# Altium schematic sheet AquaboxII.SchDoc
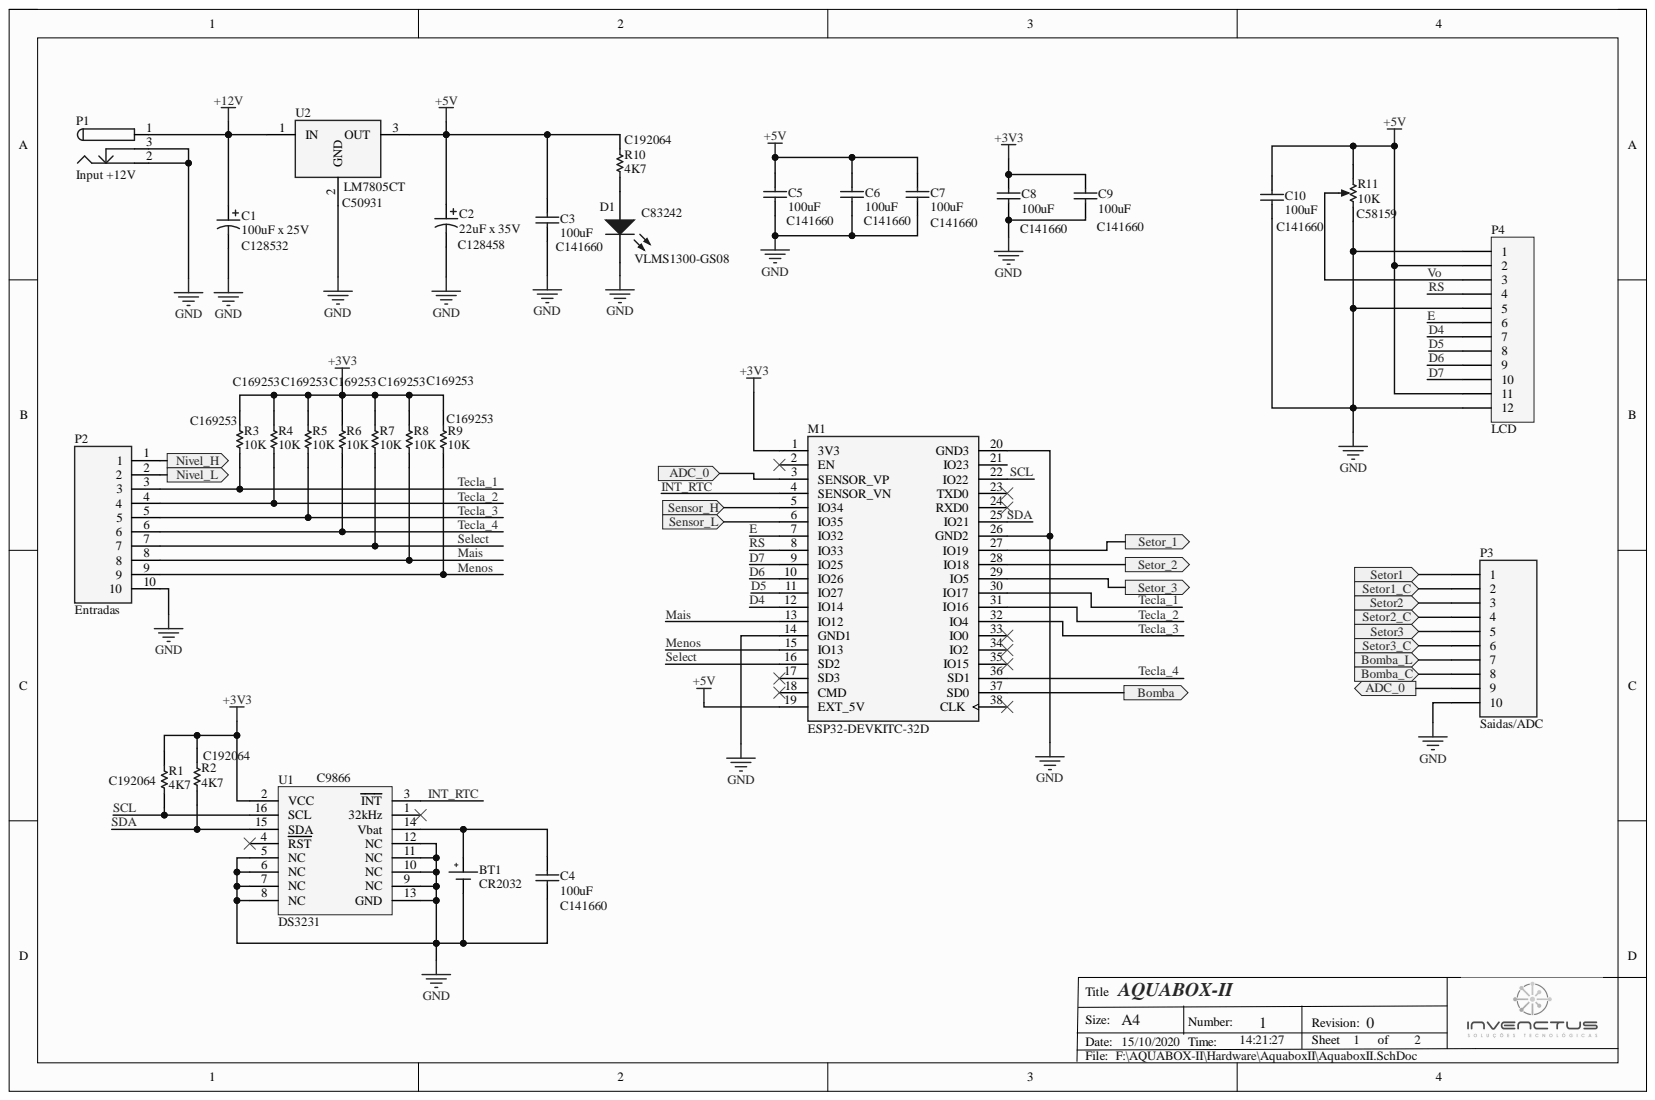
[diagram p0: AquaboxII.SchDoc]

## Parts
BT1  CR2032  [BAT CR2032]
C1  100uF x 25V  Capacitance: 100uF  Supplier Part Number 1: C128532  [Cap_Al_SMD_C6_6.6x6.6mm]
C2  22uF x 35V  Capacitance: 22uF  Supplier Part Number 1: C128458  [Cap_Al_SMD_C6_6.6x6.6mm]
C3  100uF  Supplier Part Number 1: C141660  [C2012[0805]]
C4  100uF  Supplier Part Number 1: C141660  [C2012[0805]]
C5  100uF  Supplier Part Number 1: C141660  [C2012[0805]]
C6  100uF  Supplier Part Number 1: C141660  [C2012[0805]]
C7  100uF  Supplier Part Number 1: C141660  [C2012[0805]]
C8  100uF  Supplier Part Number 1: C141660  [C2012[0805]]
C9  100uF  Supplier Part Number 1: C141660  [C2012[0805]]
C10  100uF  Supplier Part Number 1: C141660  [C2012[0805]]
D1  VLMS1300-GS08  Supplier Part Number 1: C83242  [LED-0603-R]
M1  ESP32-DEVKITC-32D  [MODULE_ESP32-DEVKITC-32D]
P1  Input +12V  [BARREL2.5]
P2  Entradas  [Block 10 Vias 5mm]
P3  Saidas/ADC  [Block 10 Vias 5mm]
P4  LCD  [HDR1X12]
R1  4K7  Supplier Part Number 1: C192064  [R2012[0805]]
R2  4K7  Supplier Part Number 1: C192064  [R2012[0805]]
R3  10K  Supplier Part Number 1: C169253  [R2012[0805]]
R4  10K  Supplier Part Number 1: C169253  [R2012[0805]]
R5  10K  Supplier Part Number 1: C169253  [R2012[0805]]
R6  10K  Supplier Part Number 1: C169253  [R2012[0805]]
R7  10K  Supplier Part Number 1: C169253  [R2012[0805]]
R8  10K  Supplier Part Number 1: C169253  [R2012[0805]]
R9  10K  Supplier Part Number 1: C169253  [R2012[0805]]
R10  4K7  Supplier Part Number 1: C192064  [R2012[0805]]
R11  10K  Supplier Part Number 1: C58159  [VR6]
U1  DS3231  Supplier Part Number 1: C9866  [SOIC-16 - W16 (300'')]
U2  LM7805CT  Supplier Part Number 1: C50931  [TO254P470X1028X1955-3P]

## Ports
ADC_0, Bomba, Bomba_C, Bomba_L, Nivel_H, Nivel_L, Sensor_H, Sensor_L, Setor1, Setor1_C, Setor2, Setor2_C, Setor3, Setor3_C, Setor_1, Setor_2, Setor_3

## Nets
+3V3: C8.2 C9.2 M1.1(3V3) R1.2 R2.2 R3.2 R4.2 R5.2 R6.2 R7.2 R8.2 R9.2 U1.2(VCC)
+5V: C2.1 C3.2 C5.2 C6.2 C7.2 C10.2 M1.19(EXT_5V) P4.2 P4.11 R10.2 R11.1 U2.3
+12V: C1.1 P1.1 U2.1
GND: BT1.2 C1.2 C2.2 C3.1 C4.1 C5.1 C6.1 C7.1 C8.1 C9.1 C10.1 D1.2 M1.14(GND1) M1.20(GND3) M1.26(GND2) P1.2 P1.3 P2.10 P3.10 P4.1 P4.5 P4.12 R11.2 U1.5(NC) U1.6(NC) U1.7(NC) U1.8(NC) U1.9(NC) U1.10(NC) U1.11(NC) U1.12(NC) U1.13(GND) U2.2
ADC_0: M1.3(SENSOR_VP) P3.9 ; port ADC_0
Bomba: M1.37(SD0) ; port Bomba
Bomba_C: P3.8 ; port Bomba_C
Bomba_L: P3.7 ; port Bomba_L
D4: M1.12(IO14) P4.7
D5: M1.11(IO27) P4.8
D6: M1.10(IO26) P4.9
D7: M1.9(IO25) P4.10
E: M1.7(IO32) P4.6
INT_RTC: M1.4(SENSOR_VN) U1.3(INT)
Mais: M1.13(IO12) P2.8 R8.1
Menos: M1.15(IO13) P2.9 R9.1
Nivel_H: P2.1 ; port Nivel_H
Nivel_L: P2.2 ; port Nivel_L
RS: M1.8(IO33) P4.4
SCL: M1.22(IO22) R1.1 U1.16(SCL)
SDA: M1.25(IO21) R2.1 U1.15(SDA)
Select: M1.16(SD2) P2.7 R7.1
Sensor_H: M1.5(IO34) ; port Sensor_H
Sensor_L: M1.6(IO35) ; port Sensor_L
Setor1: P3.1 ; port Setor1
Setor1_C: P3.2 ; port Setor1_C
Setor2: P3.3 ; port Setor2
Setor2_C: P3.4 ; port Setor2_C
Setor3: P3.5 ; port Setor3
Setor3_C: P3.6 ; port Setor3_C
Setor_1: M1.27(IO19) ; port Setor_1
Setor_2: M1.28(IO18) ; port Setor_2
Setor_3: M1.29(IO5) ; port Setor_3
Tecla_1: M1.30(IO17) P2.3 R3.1
Tecla_2: M1.31(IO16) P2.4 R4.1
Tecla_3: M1.32(IO4) P2.5 R5.1
Tecla_4: M1.36(SD1) P2.6 R6.1
Vo: P4.3 R11.3
NetBT1_1: BT1.1 C4.2 U1.14(Vbat)
NetD1_1: D1.1 R10.1

Not connected: M1 2(EN) 17(SD3) 18(CMD) 21(IO23) 23(TXD0) 24(RXD0) 33(IO0) 34(IO2) 35(IO15) 38(CLK); U1 1(32kHz) 4(RST)

## Library data of the parts
BT1 = Bat1: Singlecell Battery; Component Kind: Standard; Library Name: Basic Library.IntLib
C1 = CP: PANASONIC - EEEFK1C101P - CAP, 100µF, 16V, RADIAL, SMD REEL; Dielectric Material: Aluminium; ESR (Equivalent Series Resistance): 360mR; Leakage Current: 3uA; Life (Hours): 2000Hour; Polarity: Polar; Ripple Current: 240mA; Tolerance: 20%; Case/Package: Radial; Category: Aluminum Electrolytic Capacitors; Component Kind: Standard; ComponentLink1Description: https://datasheet.ciiva.com/19479/1357482-19479360.pdf?src-supplier=Jameco; ComponentLink1URL: https://datasheet.ciiva.com/19479/1357482-19479360.pdf?src-supplier=Jameco; ComponentLink2Description: https://datasheet.ciiva.com/11777/0900766b80e4e8b0-11777237.pdf?src-supplier=RSComponents; ComponentLink2URL: https://datasheet.ciiva.com/11777/0900766b80e4e8b0-11777237.pdf?src-supplier=RSComponents; ComponentLink3Description: https://datasheet.ciiva.com/29313/443464-da-01-en-smd-elko-vfk-d-100-uf-16v-29313488.pdf?src-supplier=Conrad; ComponentLink3URL: https://datasheet.ciiva.com/29313/443464-da-01-en-smd-elko-vfk-d-100-uf-16v-29313488.pdf?src-supplier=Conrad; ComponentLink4Description: https://datasheet.ciiva.com/2983/aba0000ce120-2983939.pdf?src-supplier=Avnet; ComponentLink4URL: https://datasheet.ciiva.com/2983/aba0000ce120-2983939.pdf?src-supplier=Avnet; Diameter: 6.3mm; Height: 5.8mm; Height - Seated (Max): 0.24inch; Impedance: 360mR; Length: 5.8mm; Library Name: Capacitores.IntLib; Manufacturer Part Number: EEEFK1C101P; Material: Aluminium; Max Operating Temperature: 105°C; Min Operating Temperature: -55°C; Mount: Surface Mount,PCB; Number of Pins: 2; Package Quantity: 1000; Packaging: Tape and Reel; Pricing 1: 5=0,12440, 50=0,08990, 150=0,08350, 500=0,07720, 2500=0,07440, 5000=0,07300, 5=0,11810, 50=0,08530, 150=0,07930, 500=0,07320, 2500=0,07060, 5000=0,06920, 5=0,60230, 50=0,43500, 150=0,40430, 500=0,37360, 2500=0,35990, 5000=0,35310, 5=0,56640, 50=0,40910, 150=0,38020, 500=0,35130, 2500=0,33850, 5000=0,33210, 5=0,07610, 50=0,05500, 150=0,05110, 500=0,04720, 2500=0,04550, 5000=0,04460, 5=0,06900, 50=0,04990, 150=0,04630, 500=0,04280, 2500=0,04130, 5000=0,04050, 5=0,69730, 50=0,50360, 150=0,46800, 500=0,43240, 2500=0,41660, 5000=0,40880, 5=9,50260, 50=6,86310, 150=6,37830, 500=5,89350, 2500=5,67800, 5000=5,57150, 5=0,37230, 50=0,26890, 150=0,24990, 500=0,23090, 2500=0,22250, 5000=0,21830, 5=6,91790, 50=4,99630, 150=4,64340, 500=4,29040, 2500=4,13360, 5000=4,05610, 5=0,79140, 50=0,57160, 150=0,53120, 500=0,49080, 2500=0,47290, 5000=0,46400, 5=0,12180, 50=0,08800, 150=0,08180, 500=0,07560, 2500=0,07280, 5000=0,07140, 5=0,08997, 50=0,06498, 150=0,06039, 500=0,05580, 2500=0,05376, 5000=0,05275 (AUD); Radiation Hardening: No; REACH SVHC: No SVHC; Ripple Current (AC): 240mA; RoHS: Yes; Signal Integrity: Cap Pol; Simulation: CAP; Stock 1: 100; Supplier 1: LCSC; Termination: SMD/SMT; Voltage: 16V; Voltage Rating: 16V; Voltage Rating (DC): 16V
C2 = CP: Cap Aluminum 22uF 50V 20% (6.3 X 5.8mm) SMD 165mA 2000h 105C T/R; Manufacturer Part Number: EEEFK1H220P; Dielectric Material: Aluminium; ESR (Equivalent Series Resistance): 880mR; Leakage Current: 3uA; Life (Hours): 2000Hour; Polarity: Polar; Case/Package: Radial; Category: Aluminum Electrolytic Capacitors; Component Kind: Standard; ComponentLink1Description: https://datasheet.ciiva.com/11777/0900766b80e4e8b0-11777316.pdf?src-supplier=RSComponents; ComponentLink1URL: https://datasheet.ciiva.com/11777/0900766b80e4e8b0-11777316.pdf?src-supplier=RSComponents; ComponentLink2Description: https://datasheet.ciiva.com/43485/1676921-43485511.pdf?src-supplier=Farnell; ComponentLink2URL: https://datasheet.ciiva.com/43485/1676921-43485511.pdf?src-supplier=Farnell; ComponentLink3Description: https://datasheet.ciiva.com/16449/aba0000ce120-16449096.pdf?src-supplier=Future+Electronics; ComponentLink3URL: https://datasheet.ciiva.com/16449/aba0000ce120-16449096.pdf?src-supplier=Future+Electronics; ComponentLink4Description: https://datasheet.ciiva.com/46376/1676921-46376472.pdf?src-supplier=Element14; ComponentLink4URL: https://datasheet.ciiva.com/46376/1676921-46376472.pdf?src-supplier=Element14; ComponentLink5Description: https://datasheet.ciiva.com/6085/1676921-6085161.pdf?src-supplier=Farnell; ComponentLink5URL: https://datasheet.ciiva.com/6085/1676921-6085161.pdf?src-supplier=Farnell; ComponentLink6Description: https://datasheet.ciiva.com/14607/70258115-14607833.pdf?src-supplier=Allied+Electronics; ComponentLink6URL: https://datasheet.ciiva.com/14607/70258115-14607833.pdf?src-supplier=Allied+Electronics; ComponentLink7Description: https://datasheet.ciiva.com/46388/58258-46388569.pdf?src-supplier=Element14; ComponentLink7URL: https://datasheet.ciiva.com/46388/58258-46388569.pdf?src-supplier=Element14; ComponentLink8Description: https://datasheet.ciiva.com/2983/aba0000ce120-2983962.pdf?src-supplier=Avnet; ComponentLink8URL: https://datasheet.ciiva.com/2983/aba0000ce120-2983962.pdf?src-supplier=Avnet; ComponentLink9Description: https://datasheet.ciiva.com/24072/aba0000ce120-24072156.pdf?src-supplier=Mouser; ComponentLink9URL: https://datasheet.ciiva.com/24072/aba0000ce120-24072156.pdf?src-supplier=Mouser; ComponentLink10Description: https://datasheet.ciiva.com/30192/aba0000ce120-30192141.pdf?src-supplier=Onlinecomponents.com; ComponentLink10URL: https://datasheet.ciiva.com/30192/aba0000ce120-30192141.pdf?src-supplier=Onlinecomponents.com; ComponentLink11Description: https://datasheet.ciiva.com/46388/1676921-46388589.pdf?src-supplier=Element14; ComponentLink11URL: https://datasheet.ciiva.com/46388/1676921-46388589.pdf?src-supplier=Element14; ComponentLink12Description: https://datasheet.ciiva.com/28691/1676921-28691087.pdf?src-supplier=Element14; ComponentLink12URL: https://datasheet.ciiva.com/28691/1676921-28691087.pdf?src-supplier=Element14; ComponentLink13Description: https://datasheet.ciiva.com/14605/70258115-14605607.pdf?src-supplier=Allied+Electronics; ComponentLink13URL: https://datasheet.ciiva.com/14605/70258115-14605607.pdf?src-supplier=Allied+Electronics; ComponentLink14Description: https://datasheet.ciiva.com/16539/aba0000ce120-16539991.pdf?src-supplier=Avnet+Asia; ComponentLink14URL: https://datasheet.ciiva.com/16539/aba0000ce120-16539991.pdf?src-supplier=Avnet+Asia; ComponentLink15Description: https://datasheet.ciiva.com/43463/58258-43463528.pdf?src-supplier=Farnell; ComponentLink15URL: https://datasheet.ciiva.com/43463/58258-43463528.pdf?src-supplier=Farnell; Contact Plating: Tin; Diameter: 6.3mm; Height: 5.8mm; Height - Seated (Max): 0.24inch; Impedance: 880mR; Lead Free: Lead Free; Length: 5.8mm; Library Name: Capacitores.IntLib; Max Operating Temperature: 105°C; Min Operating Temperature: -55°C; Mount: Surface Mount,PCB; Number of Pins: 2; Package Quantity: 1000; Packaging: Tape and Reel; Pricing 1: 5=0,13310, 50=0,09620, 150=0,08940, 500=0,08260, 2500=0,07960, 5000=0,07810, 5=0,12640, 50=0,09130, 150=0,08480, 500=0,07840, 2500=0,07550, 5000=0,07410, 5=0,64450, 50=0,46550, 150=0,43260, 500=0,39970, 2500=0,38510, 5000=0,37790, 5=0,60610, 50=0,43770, 150=0,40680, 500=0,37590, 2500=0,36220, 5000=0,35540, 5=0,08140, 50=0,05880, 150=0,05470, 500=0,05050, 2500=0,04870, 5000=0,04780, 5=0,07390, 50=0,05340, 150=0,04960, 500=0,04580, 2500=0,04410, 5000=0,04330, 5=0,74610, 50=0,53880, 150=0,50080, 500=0,46270, 2500=0,44580, 5000=0,43740, 5=10,16780, 50=7,34350, 150=6,82470, 500=6,30600, 2500=6,07550, 5000=5,96160, 5=0,39830, 50=0,28770, 150=0,26740, 500=0,24710, 2500=0,23800, 5000=0,23360, 5=7,40210, 50=5,34610, 150=4,96840, 500=4,59080, 2500=4,42290, 5000=4,34000, 5=0,84680, 50=0,61160, 150=0,56840, 500=0,52520, 2500=0,50600, 5000=0,49650, 5=0,13040, 50=0,09410, 150=0,08750, 500=0,08080, 2500=0,07790, 5000=0,07640, 5=0,09627, 50=0,06953, 150=0,06462, 500=0,05970, 2500=0,05752, 5000=0,05644 (AUD); Radiation Hardening: No; REACH SVHC: No SVHC; Ripple Current: 165mA; Ripple Current (AC): 165mA; RoHS: Yes; Signal Integrity: Cap Pol; Simulation: CAP; Stock 1: 55; Supplier 1: LCSC; Termination: SMD/SMT; Tolerance: 20%; Voltage: 50V; Voltage Rating: 50V; Voltage Rating (DC): 50V; Width: 7.8mm
C3-C10 = C: MURATA - GRM21BR60J107ME15L - SMD Multilayer Ceramic Capacitor, 100 µF, 6.3 V, 0805 [2012 Metric], ± 20%, X5R, GRM Series; Value: 1; Voltage Rating: 6.3V; Capacitance: 100uF; Case Code (Imperial): 0805; Case Code (Metric): 2012; Case/Package: 0805; Category: Ceramic Capacitors; Component Kind: Standard; ComponentLink1Description: http://www.farnell.com/datasheets/1957830.pdf?src-supplier=Farnell; ComponentLink1URL: http://www.farnell.com/datasheets/1957830.pdf?src-supplier=Farnell; ComponentLink2Description: https://search.murata.co.jp/Ceramy/image/img/A01X/G101/ENG/GRM21BR60J107ME15-01.pdf?src-supplier=Digi-Key; ComponentLink2URL: https://search.murata.co.jp/Ceramy/image/img/A01X/G101/ENG/GRM21BR60J107ME15-01.pdf?src-supplier=Digi-Key; ComponentLink3Description: http://www.farnell.com/datasheets/1957830.pdf?src-supplier=Element14; ComponentLink3URL: http://www.farnell.com/datasheets/1957830.pdf?src-supplier=Element14; ComponentLink4Description: http://www.farnell.com/datasheets/1957830.pdf?src-supplier=Newark; ComponentLink4URL: http://www.farnell.com/datasheets/1957830.pdf?src-supplier=Newark; Dielectric: X5R; Lead Free: Lead Free; Length: 0.079inch; Library Name: Capacitores.IntLib; Manufacturer Part Number: GRM21BR60J107ME15L; Max Operating Temperature: 85°C; Min Operating Temperature: -55°C; Mount: Surface Mount; Packaging: Cut Tape; Pricing 1: 1=0,67540, 10=0,49560, 30=0,46100, 100=0,42880, 500=0,41260, 1000=0,40570, 1=0,64100, 10=0,47030, 30=0,43750, 100=0,40690, 500=0,39160, 1000=0,38500, 1=3,26920, 10=2,39890, 30=2,23160, 100=2,07540, 500=1,99720, 1000=1,96380, 1=3,07460, 10=2,25610, 30=2,09870, 100=1,95180, 500=1,87830, 1000=1,84690, 1=0,41320, 10=0,30320, 30=0,28200, 100=0,26230, 500=0,25240, 1000=0,24820, 1=0,37480, 10=0,27500, 30=0,25580, 100=0,23790, 500=0,22900, 1000=0,22510, 1=3,78460, 10=2,77710, 30=2,58330, 100=2,40250, 500=2,31210, 1000=2,27330, 1=51,57850, 10=37,84770, 30=35,20710, 100=32,74270, 500=31,51040, 1000=30,98230, 1=2,02070, 10=1,48280, 30=1,37930, 100=1,28280, 500=1,23450, 1000=1,21380, 1=37,54910, 10=27,55310, 30=25,63080, 100=23,83670, 500=22,93950, 1000=22,55510, 1=4,29540, 10=3,15190, 30=2,93200, 100=2,72680, 500=2,62420, 1000=2,58020, 1=0,66130, 10=0,48520, 30=0,45140, 100=0,41980, 500=0,40400, 1000=0,39720, 1=0,48833, 10=0,35833, 30=0,33333, 100=0,31000, 500=0,29833, 1000=0,29333 (AUD); REACH SVHC: No SVHC; RoHS: Yes; Simulation: CAP; Stock 1: 26681; Supplier 1: LCSC; Thickness: 0.057inch; Tolerance: 20%; Voltage Rating (DC): 6.3V; Width: 0.049inch
D1 = LED: LED Uni-Color Red 639nm 2-Pin Chip LED T/R; Dominant Wavelength: 631nm; Forward Current: 20mA; Forward Voltage: 2V; Lens Style: Rectangular,Clear; Luminous Intensity: 54mcd; Max Power Dissipation: 75mW; Number of Elements: 1; Reverse Voltage: 5V; Viewing Angle: 130°; Case Code (Imperial): 0603; Case Code (Metric): 1608; Case/Package: 0603; Category: LEDs; Color: Red; Component Kind: Standard; ComponentLink1Description: https://datasheet.ciiva.com/2114/vlmo1300-2114929.pdf?src-supplier=Digi-Key; ComponentLink1URL: https://datasheet.ciiva.com/2114/vlmo1300-2114929.pdf?src-supplier=Digi-Key; ComponentLink2Description: https://datasheet.ciiva.com/2114/vlmo1300-2114930.pdf?src-supplier=Digi-Key; ComponentLink2URL: https://datasheet.ciiva.com/2114/vlmo1300-2114930.pdf?src-supplier=Digi-Key; ComponentLink3Description: https://datasheet.ciiva.com/2114/vlmo1300-2114931.pdf?src-supplier=Digi-Key; ComponentLink3URL: https://datasheet.ciiva.com/2114/vlmo1300-2114931.pdf?src-supplier=Digi-Key; ComponentLink4Description: https://datasheet.ciiva.com/16510/vlmo1300-16510985.pdf?src-supplier=Future+Electronics; ComponentLink4URL: https://datasheet.ciiva.com/16510/vlmo1300-16510985.pdf?src-supplier=Future+Electronics; ComponentLink5Description: https://datasheet.ciiva.com/26322/vlmo1300-26322153.pdf?src-supplier=Element14; ComponentLink5URL: https://datasheet.ciiva.com/26322/vlmo1300-26322153.pdf?src-supplier=Element14; ComponentLink6Description: https://datasheet.ciiva.com/8028/vlmo1300-8028047.pdf?src-supplier=Element14; ComponentLink6URL: https://datasheet.ciiva.com/8028/vlmo1300-8028047.pdf?src-supplier=Element14; ComponentLink7Description: https://datasheet.ciiva.com/9333/vlmo1300-9333743.pdf?src-supplier=Avnet; ComponentLink7URL: https://datasheet.ciiva.com/9333/vlmo1300-9333743.pdf?src-supplier=Avnet; Contact Plating: Tin,Matte; Depth: 0.8mm; Height: 0.8mm; Illumination Color: Red; Lead Free: Lead Free; Length: 1.6mm; Library Name: Basic Library.IntLib; Manufacturer Part Number: VLMS1300-GS08; Max Operating Temperature: 85°C; Min Operating Temperature: -35°C; Mount: Surface Mount; Number of LEDs: 1; Number of Pins: 2; Package Quantity: 3000; Packaging: Tape and Reel; Power Dissipation: 75mW; Power Rating: 75mW; Pricing 1: 10=0,07360, 100=0,05470, 300=0,05130, 1000=0,04780, 5000=0,04630, 10000=0,04550, 10=0,06990, 100=0,05190, 300=0,04870, 1000=0,04540, 5000=0,04390, 10000=0,04320, 10=0,35640, 100=0,26490, 300=0,24820, 1000=0,23140, 5000=0,22390, 10000=0,22020, 10=0,33510, 100=0,24920, 300=0,23340, 1000=0,21760, 5000=0,21060, 10000=0,20710, 10=0,04500, 100=0,03350, 300=0,03140, 1000=0,02920, 5000=0,02830, 10000=0,02780, 10=0,04090, 100=0,03040, 300=0,02840, 1000=0,02650, 5000=0,02570, 10000=0,02520, 10=0,41250, 100=0,30670, 300=0,28730, 1000=0,26780, 5000=0,25920, 10000=0,25490, 10=5,62230, 100=4,18010, 300=3,91520, 1000=3,65030, 5000=3,53260, 10000=3,47440, 10=0,22030, 100=0,16380, 300=0,15340, 1000=0,14300, 5000=0,13840, 10000=0,13610, 10=4,09310, 100=3,04310, 300=2,85020, 1000=2,65740, 5000=2,57170, 10000=2,52940, 10=0,46820, 100=0,34810, 300=0,32610, 1000=0,30400, 5000=0,29420, 10000=0,28930, 10=0,07210, 100=0,05360, 300=0,05020, 1000=0,04680, 5000=0,04530, 10000=0,04450, 10=0,05323, 100=0,03958, 300=0,03707, 1000=0,03456, 5000=0,03345, 10000=0,03290 (AUD); Radiation Hardening: No; REACH SVHC: Unknown; RoHS: Yes; Simulation: LED0; Stock 1: 3280; Supplier 1: LCSC; Test Current: 20mA; Wavelength: 631nm; Width: 0.8mm
M1 = ESP32-DEVKITC-32D: - Transceiver; 802.11 b/g/n _Wi-Fi, WiFi, WLAN_, BluetoothÂ® Smart Ready 4.x Dual Mode For Use With ESP-WROOM-32; MF: Espressif Systems; Availability: Unavailable; Component Kind: Standard; Library Name: Modulos_Library.IntLib; MP: ESP32-DEVKITC-32D; Package: None; Price: None; SnapEDA_Link: https://www.snapeda.com/parts/ESP32-DEVKITC-32D/Espressif%20Systems/view-part/2777395/?ref=snap
P1 = PWR2.5: Low Voltage Power Supply Connector; Manufacturer: Memory Protection Devices; Manufacturer Part Number: EJ503A; Component Kind: Standard; Library Name: Connectors Library.IntLib; Pricing 1: 1=2.7, 10=2.447, 100=2.0977, 500=1.74806, 1000=1.49834 (USD); Stock 1: 1084; Supplier 1: Digi-Key; Supplier Part Number 1: EJ503A-ND
P2 P3 = Block 10 Vias 5mm: Component Kind: Standard; Library Name: Conectores.IntLib
P4 = Header 12: Header, 12-Pin; Component Kind: Standard; LatestRevisionDate: 17-Jul-2002; LatestRevisionNote: Re-released for DXP Platform.; Library Name: Miscellaneous Connectors.IntLib; Signal Integrity: Connector; Publisher: Altium Limited
R1 R2 R10 = R: PANASONIC - ERJ6ENF4701V - Ytmonterat chipmotstånd, Tjockfilm, 0805 [2012 Metrisk], 4.7 kohm, ERJ6E Serien, 150 V, Tjockfilm; Manufacturer Part Number: ERJ-6ENF4701V; Max Power Dissipation: 125mW; Resistance: 4.7kR; Temperature Coefficient: 100ppm/°C; Tolerance: 1%; Value: 0; Voltage Rating: 150V; Case Code (Imperial): 0805; Case Code (Metric): 2012; Case/Package: 0805; Category: Chip SMD Resistors; Component Kind: Standard; ComponentLink1Description: https://datasheet.ciiva.com/11821/0900766b80f80784-11821673.pdf?src-supplier=RSComponents; ComponentLink1URL: https://datasheet.ciiva.com/11821/0900766b80f80784-11821673.pdf?src-supplier=RSComponents; ComponentLink2Description: https://datasheet.ciiva.com/28514/426256-28514229.pdf?src-supplier=Element14; ComponentLink2URL: https://datasheet.ciiva.com/28514/426256-28514229.pdf?src-supplier=Element14; ComponentLink3Description: https://datasheet.ciiva.com/16200/aoa0000ce2-16200217.pdf?src-supplier=Future+Electronics; ComponentLink3URL: https://datasheet.ciiva.com/16200/aoa0000ce2-16200217.pdf?src-supplier=Future+Electronics; ComponentLink4Description: https://datasheet.ciiva.com/7830/426256-7830750.pdf?src-supplier=Element14; ComponentLink4URL: https://datasheet.ciiva.com/7830/426256-7830750.pdf?src-supplier=Element14; ComponentLink5Description: https://datasheet.ciiva.com/16777/70260748-16777271.pdf?src-supplier=Allied+Electronics; ComponentLink5URL: https://datasheet.ciiva.com/16777/70260748-16777271.pdf?src-supplier=Allied+Electronics; ComponentLink6Description: https://datasheet.ciiva.com/9117/aoa0000ce2-9117307.pdf?src-supplier=Avnet; ComponentLink6URL: https://datasheet.ciiva.com/9117/aoa0000ce2-9117307.pdf?src-supplier=Avnet; ComponentLink7Description: https://datasheet.ciiva.com/16769/70260748-16769116.pdf?src-supplier=Allied+Electronics; ComponentLink7URL: https://datasheet.ciiva.com/16769/70260748-16769116.pdf?src-supplier=Allied+Electronics; ComponentLink8Description: https://datasheet.ciiva.com/24124/aoa0000ce2-24124253.pdf?src-supplier=Mouser; ComponentLink8URL: https://datasheet.ciiva.com/24124/aoa0000ce2-24124253.pdf?src-supplier=Mouser; Contact Plating: Tin; Depth: 1.25mm; Features: Automotive AEC-Q200; Height: 0.6mm; Lead Free: Lead Free; Length: 2mm; Max Operating Temperature: 155°C; Military Standard: Not; Min Operating Temperature: -55°C; Mount: Surface Mount; Number of Pins: 2; Number of Terminations: 2; Package Quantity: 1; Packaging: Tape and Reel; Power Rating: 125mW; Pricing 1: 50=0,02140, 500=0,01570, 1500=0,01470, 5000=0,01360, 25000=0,01320, 50000=0,01300, 50=0,02030, 500=0,01490, 1500=0,01390, 5000=0,01290, 25000=0,01250, 50000=0,01230, 50=0,10340, 500=0,07610, 1500=0,07100, 5000=0,06600, 25000=0,06380, 50000=0,06270, 50=0,09720, 500=0,07150, 1500=0,06680, 5000=0,06210, 25000=0,06000, 50000=0,05900, 50=0,01310, 500=0,00960, 1500=0,00900, 5000=0,00830, 25000=0,00810, 50000=0,00790, 50=0,01190, 500=0,00870, 1500=0,00810, 5000=0,00760, 25000=0,00730, 50000=0,00720, 50=0,11970, 500=0,08800, 1500=0,08220, 5000=0,07640, 25000=0,07380, 50000=0,07260, 50=1,63110, 500=1,20000, 1500=1,12080, 5000=1,04160, 25000=1,00640, 50000=0,98900, 50=0,06390, 500=0,04700, 1500=0,04390, 5000=0,04080, 25000=0,03940, 50000=0,03870, 50=1,18740, 500=0,87360, 1500=0,81590, 5000=0,75830, 25000=0,73260, 50000=0,72000, 50=0,13580, 500=0,09990, 1500=0,09330, 5000=0,08670, 25000=0,08380, 50000=0,08240, 50=0,02090, 500=0,01540, 1500=0,01440, 5000=0,01340, 25000=0,01290, 50000=0,01270, 50=0,01544, 500=0,01136, 1500=0,01061, 5000=0,00986, 25000=0,00953, 50000=0,00936 (AUD); Radiation Hardening: No; REACH SVHC: No SVHC; Resistor Type: Thick Film; RoHS: Yes; Signal Integrity: Res Semi; Simulation: RES; Stock 1: 1950; Supplier 1: LCSC; Termination: SMD/SMT; Voltage Rating (DC): 150V; Weight: 0.000194oz; Width: 0.049inch; Working Voltage: 150V; Library Name: Resistores.IntLib
  R10: ComponentLink9Description: https://datasheet.ciiva.com/22667/1678782-22667902.pdf?src-supplier=Element14; ComponentLink9URL: https://datasheet.ciiva.com/22667/1678782-22667902.pdf?src-supplier=Element14
R3-R9 = R: PANASONIC - ERJ6ENF1002V - Ytmonterat chipmotstånd, Tjockfilm, 0805 [2012 Metrisk], 10 kohm, ERJ6E Serien, 150 V, Tjockfilm; Manufacturer Part Number: ERJ-6ENF1002V; Max Power Dissipation: 125mW; Resistance: 10kR; Temperature Coefficient: 100ppm/°C; Tolerance: 1%; Value: 0; Voltage Rating: 150V; Case Code (Imperial): 0805; Case Code (Metric): 2012; Case/Package: 0805; Category: Chip SMD Resistors; Component Kind: Standard; ComponentLink1Description: https://datasheet.ciiva.com/11808/0900766b80f80784-11808080.pdf?src-supplier=RSComponents; ComponentLink1URL: https://datasheet.ciiva.com/11808/0900766b80f80784-11808080.pdf?src-supplier=RSComponents; ComponentLink2Description: https://datasheet.ciiva.com/16514/aoa0000ce2-16514021.pdf?src-supplier=Avnet+Asia; ComponentLink2URL: https://datasheet.ciiva.com/16514/aoa0000ce2-16514021.pdf?src-supplier=Avnet+Asia; ComponentLink3Description: https://datasheet.ciiva.com/27055/1988971-27055733.pdf?src-supplier=Jameco; ComponentLink3URL: https://datasheet.ciiva.com/27055/1988971-27055733.pdf?src-supplier=Jameco; ComponentLink4Description: https://datasheet.ciiva.com/16759/70260748-16759350.pdf?src-supplier=Allied+Electronics; ComponentLink4URL: https://datasheet.ciiva.com/16759/70260748-16759350.pdf?src-supplier=Allied+Electronics; ComponentLink5Description: https://datasheet.ciiva.com/16725/70260748-16725031.pdf?src-supplier=Allied+Electronics; ComponentLink5URL: https://datasheet.ciiva.com/16725/70260748-16725031.pdf?src-supplier=Allied+Electronics; ComponentLink6Description: https://datasheet.ciiva.com/46372/1678782-46372714.pdf?src-supplier=Element14; ComponentLink6URL: https://datasheet.ciiva.com/46372/1678782-46372714.pdf?src-supplier=Element14; ComponentLink7Description: https://datasheet.ciiva.com/46372/1678782-46372715.pdf?src-supplier=Element14; ComponentLink7URL: https://datasheet.ciiva.com/46372/1678782-46372715.pdf?src-supplier=Element14; Contact Plating: Tin; Depth: 1.25mm; Features: Automotive AEC-Q200; Height: 0.6mm; Lead Free: Lead Free; Length: 2mm; Max Operating Temperature: 155°C; Military Standard: Not; Min Operating Temperature: -55°C; Mount: Surface Mount; Number of Pins: 2; Number of Terminations: 2; Package Quantity: 5000; Packaging: Tape and Reel; Power Rating: 125mW; Pricing 1: 50=0,01490, 500=0,01100, 1500=0,01030, 5000=0,00950, 25000=0,00920, 50000=0,00900, 50=0,01420, 500=0,01040, 1500=0,00970, 5000=0,00900, 25000=0,00870, 50000=0,00860, 50=0,07230, 500=0,05320, 1500=0,04960, 5000=0,04610, 25000=0,04460, 50000=0,04380, 50=0,06800, 500=0,05000, 1500=0,04670, 5000=0,04340, 25000=0,04190, 50000=0,04120, 50=0,00910, 500=0,00670, 1500=0,00630, 5000=0,00580, 25000=0,00560, 50000=0,00550, 50=0,00830, 500=0,00610, 1500=0,00570, 5000=0,00530, 25000=0,00510, 50000=0,00500, 50=0,08370, 500=0,06150, 1500=0,05750, 5000=0,05340, 25000=0,05160, 50000=0,05070, 50=1,14020, 500=0,83860, 1500=0,78320, 5000=0,72780, 25000=0,70310, 50000=0,69100, 50=0,04470, 500=0,03290, 1500=0,03070, 5000=0,02850, 25000=0,02750, 50000=0,02710, 50=0,83010, 500=0,61050, 1500=0,57020, 5000=0,52990, 25000=0,51190, 50000=0,50300, 50=0,09500, 500=0,06980, 1500=0,06520, 5000=0,06060, 25000=0,05860, 50000=0,05750, 50=0,01460, 500=0,01080, 1500=0,01000, 5000=0,00930, 25000=0,00900, 50000=0,00890, 50=0,01080, 500=0,00794, 1500=0,00742, 5000=0,00689, 25000=0,00666, 50000=0,00654 (AUD); Radiation Hardening: No; REACH SVHC: No SVHC; Resistor Type: Thick Film; RoHS: Yes; Signal Integrity: Res Semi; Simulation: RES; Stock 1: 40250; Supplier 1: LCSC; Termination: SMD/SMT; Voltage Rating (DC): 150V; Width: 0.049inch; Working Voltage: 150V; Library Name: Resistores.IntLib
R11 = R Pot: Res Cermet Trimmer 10K Ohm 10% 0.5W(1/2W) 1(Elec)/1(Mech)Turn 2.77mm (6.6 X 6.99 X 4.7mm) Pin Thru-Hole Tube; Manufacturer Part Number: 3362P-1-103LF; Insulation Resistance: 1GR; Max Power Dissipation: 500mW; Number of Turns: 1; Pitch: 2.54mm; Resistance: 10kR; Temperature Coefficient: 100ppm/°C; Voltage Rating: 300V; Category: Variable Resistors and Potentiometers; Color: Blue; Component Kind: Standard; ComponentLink1Description: https://datasheet.ciiva.com/11832/0900766b8069ccf8-11832268.pdf?src-supplier=RSComponents; ComponentLink1URL: https://datasheet.ciiva.com/11832/0900766b8069ccf8-11832268.pdf?src-supplier=RSComponents; ComponentLink2Description: https://datasheet.ciiva.com/19481/1158096-19481852.pdf?src-supplier=Jameco; ComponentLink2URL: https://datasheet.ciiva.com/19481/1158096-19481852.pdf?src-supplier=Jameco; ComponentLink3Description: https://datasheet.ciiva.com/29122/447330-da-01-en-trimmer-tht-3362p-1-103lf-10k-0-5w-29122261.pdf?src-supplier=Conrad; ComponentLink3URL: https://datasheet.ciiva.com/29122/447330-da-01-en-trimmer-tht-3362p-1-103lf-10k-0-5w-29122261.pdf?src-supplier=Conrad; ComponentLink4Description: https://datasheet.ciiva.com/30248/3362-30248694.pdf?src-supplier=Onlinecomponents.com; ComponentLink4URL: https://datasheet.ciiva.com/30248/3362-30248694.pdf?src-supplier=Onlinecomponents.com; ComponentLink5Description: https://datasheet.ciiva.com/14108/mk3362-dat-e-14108843.pdf?src-supplier=Distrelec; ComponentLink5URL: https://datasheet.ciiva.com/14108/mk3362-dat-e-14108843.pdf?src-supplier=Distrelec; ComponentLink6Description: https://datasheet.ciiva.com/20164/68-0905e-20164246.pdf?src-supplier=Rapid+Electronics; ComponentLink6URL: https://datasheet.ciiva.com/20164/68-0905e-20164246.pdf?src-supplier=Rapid+Electronics; ComponentLink7Description: https://datasheet.ciiva.com/3266/3362-3266299.pdf?src-supplier=Avnet; ComponentLink7URL: https://datasheet.ciiva.com/3266/3362-3266299.pdf?src-supplier=Avnet; ComponentLink8Description: https://datasheet.ciiva.com/18411/70153603-18411579.pdf?src-supplier=Allied+Electronics; ComponentLink8URL: https://datasheet.ciiva.com/18411/70153603-18411579.pdf?src-supplier=Allied+Electronics; ComponentLink9Description: https://datasheet.ciiva.com/2156/3362-2156381.pdf?src-supplier=Digi-Key; ComponentLink9URL: https://datasheet.ciiva.com/2156/3362-2156381.pdf?src-supplier=Digi-Key; Contact Plating: Tin,Matte; Depth: 6.99mm; Height: 0.185inch; Lead Free: Lead Free; Length: 6.6mm; Max Operating Temperature: 125°C; Military Standard: Not; Min Operating Temperature: -55°C; Mount: Through Hole,PCB; Number of Pins: 3; Package Quantity: 250; Packaging: Rail/Tube; Power Rating: 500mW; Pricing 1: 1=0,31090, 10=0,23750, 30=0,22400, 100=0,21050, 500=0,20450, 1000=0,20160, 1=0,29500, 10=0,22540, 30=0,21260, 100=0,19980, 500=0,19410, 1000=0,19130, 1=1,50470, 10=1,14960, 30=1,08430, 100=1,01900, 500=0,99000, 1000=0,97580, 1=1,41520, 10=1,08110, 30=1,01980, 100=0,95840, 500=0,93110, 1000=0,91770, 1=0,19020, 10=0,14530, 30=0,13700, 100=0,12880, 500=0,12510, 1000=0,12330, 1=0,17250, 10=0,13180, 30=0,12430, 100=0,11680, 500=0,11350, 1000=0,11190, 1=1,74200, 10=1,33080, 30=1,25530, 100=1,17970, 500=1,14610, 1000=1,12960, 1=23,74020, 10=18,13700, 30=17,10720, 100=16,07740, 500=15,61960, 1000=15,39430, 1=0,93010, 10=0,71060, 30=0,67020, 100=0,62990, 500=0,61190, 1000=0,60310, 1=17,28290, 10=13,20370, 30=12,45400, 100=11,70430, 500=11,37110, 1000=11,20710, 1=1,97710, 10=1,51040, 30=1,42470, 100=1,33890, 500=1,30080, 1000=1,28200, 1=0,30440, 10=0,23250, 30=0,21930, 100=0,20610, 500=0,20020, 1000=0,19740, 1=0,22477, 10=0,17172, 30=0,16197, 100=0,15222, 500=0,14788, 1000=0,14575 (AUD); Radiation Hardening: No; REACH SVHC: No SVHC; Resistor Type: Cermet; RoHS: Yes; Simulation: POT; Stock 1: 2191; Supplier 1: LCSC; Taper: Linear; Termination: Pin; Tolerance: 10%; Type: Potentiometer; Weight: 0.02oz; Width: 6.6mm; Library Name: Resistores.IntLib
U1 = DS3231: MAXIM INTEGRATED PRODUCTS - DS3231SN#T&R - IC, RTC, Datum-/Zeitformat (Tag/Datum/Monat/Jahr, hh:mm:ss), I2C, 2.3V-5.5V Versorgung, SOIC-16; Date Format: YY-MM-DD-dd; Interface: I2C,Serial,2-Wire; Logic Function: Clock; Max Supply Voltage: 5.5V; Min Supply Voltage: 2.3V; Operating Supply Voltage: 3.3V; Case/Package: SOIC; Category: Real Time Clocks; Component Kind: Standard; ComponentLink1Description: http://datasheets.maxim-ic.com/en/ds/DS3231.pdf?src-supplier=Avnet+Europe; ComponentLink1URL: http://datasheets.maxim-ic.com/en/ds/DS3231.pdf?src-supplier=Avnet+Europe; ComponentLink2Description: http://www.mouser.com/ds/2/256/DS3231-102175.pdf?src-supplier=Mouser; ComponentLink2URL: http://www.mouser.com/ds/2/256/DS3231-102175.pdf?src-supplier=Mouser; ComponentLink3Description: http://datasheets.maximintegrated.com/en/ds/DS3231.pdf?src-supplier=Avnet; ComponentLink3URL: http://datasheets.maximintegrated.com/en/ds/DS3231.pdf?src-supplier=Avnet; ComponentLink4Description: https://datasheet.ciiva.com/17307/ds3231-17307128.pdf?src-supplier=Avnet+Europe; ComponentLink4URL: https://datasheet.ciiva.com/17307/ds3231-17307128.pdf?src-supplier=Avnet+Europe; ComponentLink5Description: https://datasheet.ciiva.com/12291/ds3231-102175-12291260.pdf?src-supplier=Mouser; ComponentLink5URL: https://datasheet.ciiva.com/12291/ds3231-102175-12291260.pdf?src-supplier=Mouser; ComponentLink6Description: https://datasheet.ciiva.com/14244/ds3231-14244637.pdf?src-supplier=Avnet; ComponentLink6URL: https://datasheet.ciiva.com/14244/ds3231-14244637.pdf?src-supplier=Avnet; ComponentLink7Description: https://datasheet.ciiva.com/14244/ds3231-14244733.pdf?src-supplier=Avnet; ComponentLink7URL: https://datasheet.ciiva.com/14244/ds3231-14244733.pdf?src-supplier=Avnet; Contact Plating: Tin,Matte; Lead Free: Lead Free; Library Name: Components Library.IntLib; Manufacturer: Maxim; Manufacturer Part Number: DS3231SN#T&R; Max Operating Temperature: 85°C; Min Operating Temperature: -40°C; Mount: Surface Mount; Number of Pins: 16; Package Quantity: 1000; Packaging: Tape and Reel; Pricing 1: 1=2,86310, 10=2,38590, 30=2,25220, 100=2,13690, 500=2,02400, 1000=2,00320, 1=2,71700, 10=2,26410, 30=2,13730, 100=2,02790, 500=1,92070, 1000=1,90100, 1=13,85800, 10=11,54840, 30=10,90120, 100=10,34330, 500=9,79660, 1000=9,69620, 1=13,03290, 10=10,86080, 30=10,25210, 100=9,72750, 500=9,21330, 1000=9,11880, 1=1,75130, 10=1,45950, 30=1,37770, 100=1,30720, 500=1,23810, 1000=1,22540, 1=1,58860, 10=1,32390, 30=1,24970, 100=1,18570, 500=1,12300, 1000=1,11150, 1=16,04260, 10=13,36880, 30=12,61960, 100=11,97380, 500=11,34090, 1000=11,22460, 1=218,63660, 10=182,19710, 30=171,98700, 100=163,18530, 500=154,55950, 1000=152,97510, 1=8,56570, 10=7,13810, 30=6,73810, 100=6,39320, 500=6,05530, 1000=5,99320, 1=159,16730, 10=132,63940, 30=125,20650, 100=118,79880, 500=112,51920, 1000=111,36580, 1=18,20790, 10=15,17330, 30=14,32300, 100=13,59000, 500=12,87160, 1000=12,73970, 1=2,80300, 10=2,33580, 30=2,20490, 100=2,09210, 500=1,98150, 1000=1,96120, 1=2,07000, 10=1,72500, 30=1,62833, 100=1,54500, 500=1,46333, 1000=1,44833 (AUD); Radiation Hardening: No; RoHS: Yes; Stock 1: 33025; Supplier 1: LCSC; Time Format: HH:MM:SS
U2 = LM7805CT: Series 3-Terminal Positive Regulators; Manufacturer: Texas Instruments; Manufacturer Part Number: LM7805CT/NOPB; Accuracy: 2%; Dropout Voltage: 2V; Max Input Voltage: 35V; Max Output Current: 1A; Min Input Voltage: 10V; Number of Outputs: 1; Output Type: Fixed; Output Voltage: 5V; Quiescent Current: 8mA; Arrow Part Number: LM7805CT/NOPB; Arrow Price/Stock: https://www.arrow.com/en/products/lm7805ctnopb/texas-instruments; Case/Package: TO-220; Category: Voltage Regulators - Linear; Component Kind: Standard; ComponentLink1Description: https://datasheet.ciiva.com/45987/902071-45987836.pdf?src-supplier=Jameco; ComponentLink1URL: https://datasheet.ciiva.com/45987/902071-45987836.pdf?src-supplier=Jameco; ComponentLink2Description: https://datasheet.ciiva.com/15083/lm7805c-15083790.pdf?src-supplier=Digi-Key; ComponentLink2URL: https://datasheet.ciiva.com/15083/lm7805c-15083790.pdf?src-supplier=Digi-Key; ComponentLink3Description: https://datasheet.ciiva.com/14280/lm340-n-14280911.pdf?src-supplier=Avnet; ComponentLink3URL: https://datasheet.ciiva.com/14280/lm340-n-14280911.pdf?src-supplier=Avnet; Datasheet Link: http://www.ti.com/lit/gpn/lm7800; Height: 4.7mm; Lead Free: Contains Lead; Length: 10.16mm; Manufacturer_Name: Texas Instruments; Manufacturer_Part_Number: LM7805CT/NOPB; Max Operating Temperature: 125°C; Min Operating Temperature: 0°C; Mount: Through Hole; Mouser Part Number: 926-LM7805CT/NOPB; Mouser Price/Stock: https://www.mouser.co.uk/ProductDetail/Texas-Instruments/LM7805CT-NOPB?qs=OYMYEaN9QmBS2GvaX6GSkQ%3D%3D; Number of Pins: 3; Number of Regulators: 1; Output Current: 1A; Output Voltage Accuracy: 2%; Package Quantity: 45; Packaging: Rail/Tube; Polarity: Positive; Radiation Hardening: No; RoHS: Yes; Supplier 1: LCSC; Width: 4.7mm; Library Name: Reguladores.IntLib
